# Altium schematic sheet DIGITAL_INPUT.SchDoc(DIGITAL_INPUT)
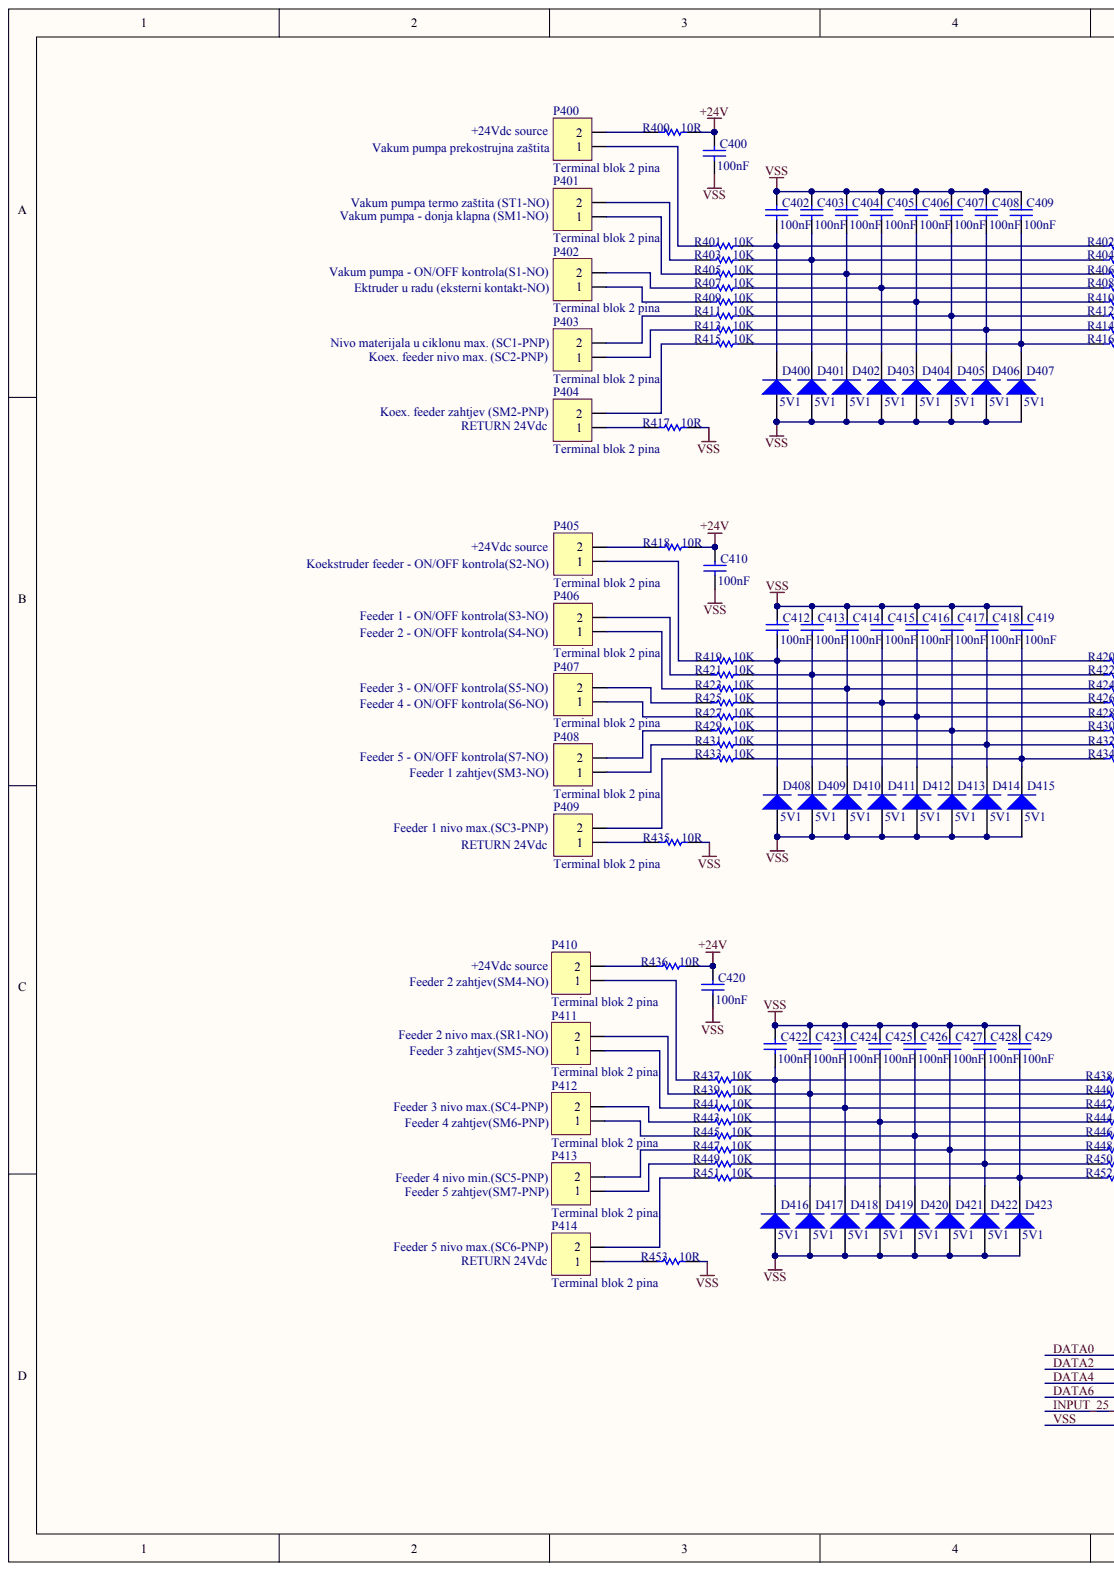
[diagram p0: DIGITAL_INPUT.SchDoc, left]
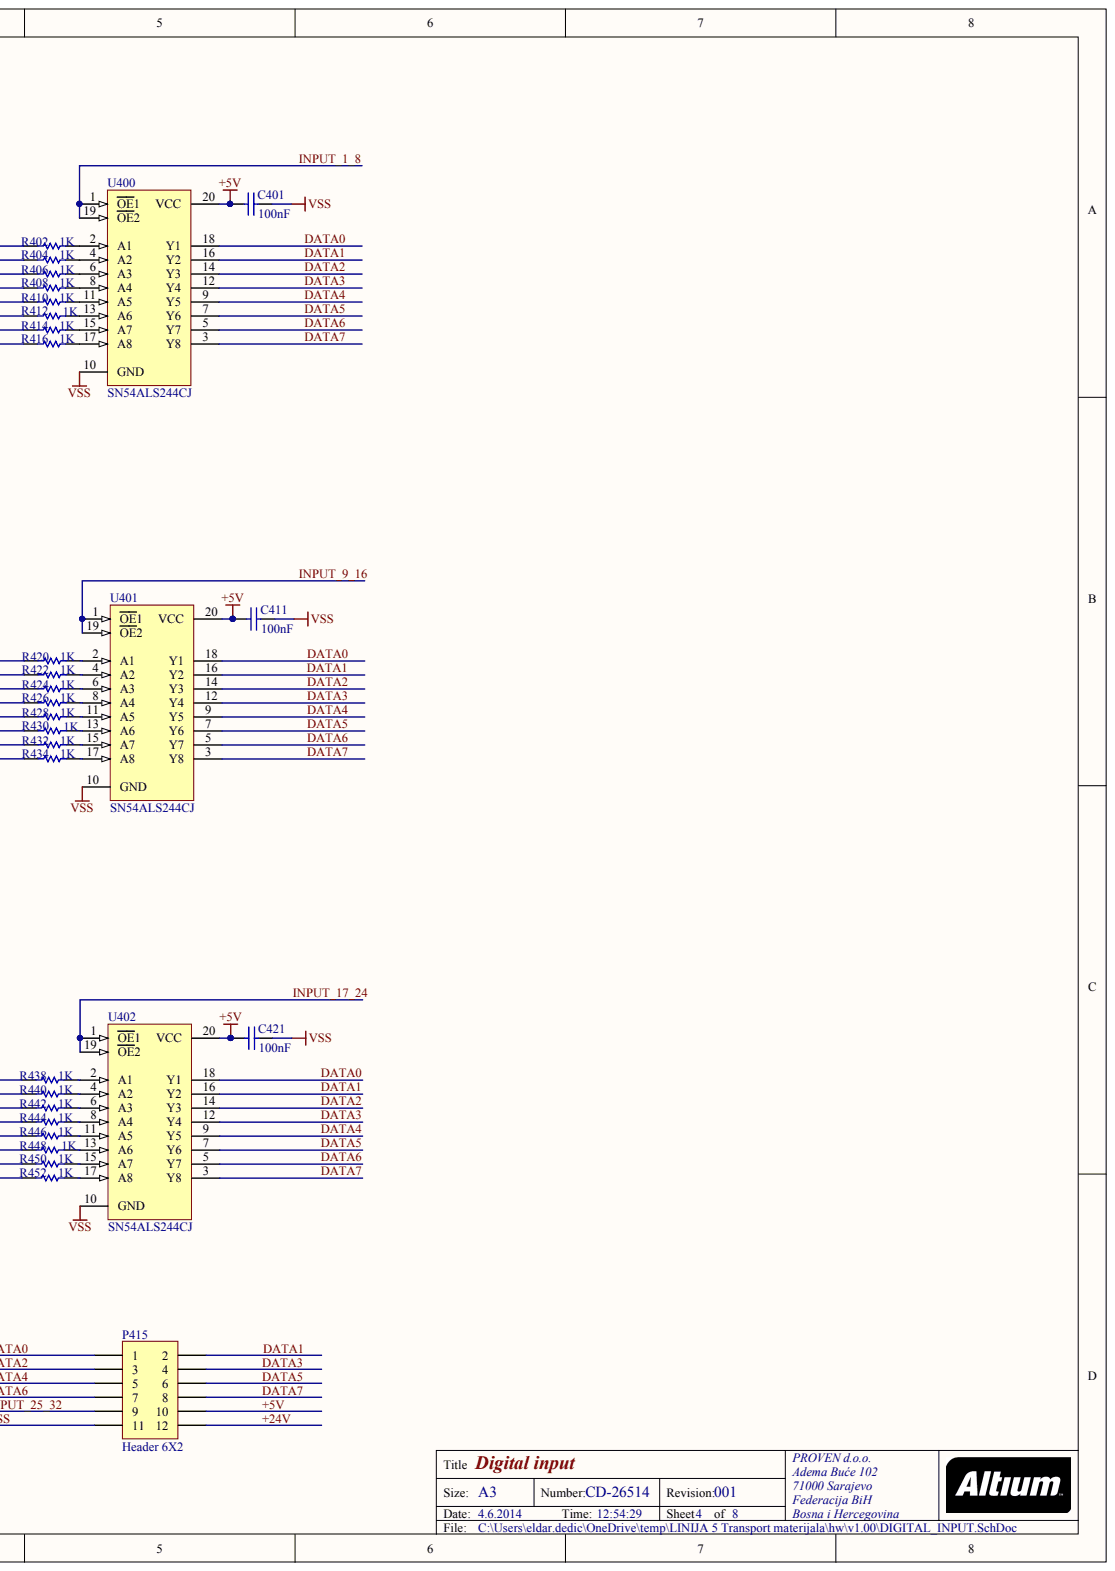
[diagram p1: DIGITAL_INPUT.SchDoc, right]

## Parts
C400  value 100nF
C401  value 100nF
C402  value 100nF
C403  value 100nF
C404  value 100nF
C405  value 100nF
C406  value 100nF
C407  value 100nF
C408  value 100nF
C409  value 100nF
C410  value 100nF
C411  value 100nF
C412  value 100nF
C413  value 100nF
C414  value 100nF
C415  value 100nF
C416  value 100nF
C417  value 100nF
C418  value 100nF
C419  value 100nF
C420  value 100nF
C421  value 100nF
C422  value 100nF
C423  value 100nF
C424  value 100nF
C425  value 100nF
C426  value 100nF
C427  value 100nF
C428  value 100nF
C429  value 100nF
D400  5V1
D401  5V1
D402  5V1
D403  5V1
D404  5V1
D405  5V1
D406  5V1
D407  5V1
D408  5V1
D409  5V1
D410  5V1
D411  5V1
D412  5V1
D413  5V1
D414  5V1
D415  5V1
D416  5V1
D417  5V1
D418  5V1
D419  5V1
D420  5V1
D421  5V1
D422  5V1
D423  5V1
P400  Terminal blok 2 pina
P401  Terminal blok 2 pina
P402  Terminal blok 2 pina
P403  Terminal blok 2 pina
P404  Terminal blok 2 pina
P405  Terminal blok 2 pina
P406  Terminal blok 2 pina
P407  Terminal blok 2 pina
P408  Terminal blok 2 pina
P409  Terminal blok 2 pina
P410  Terminal blok 2 pina
P411  Terminal blok 2 pina
P412  Terminal blok 2 pina
P413  Terminal blok 2 pina
P414  Terminal blok 2 pina
P415  Header 6X2
R400  10R
R401  10K
R402  1K
R403  10K
R404  1K
R405  10K
R406  1K
R407  10K
R408  1K
R409  10K
R410  1K
R411  10K
R412  1K
R413  10K
R414  1K
R415  10K
R416  1K
R417  10R
R418  10R
R419  10K
R420  1K
R421  10K
R422  1K
R423  10K
R424  1K
R425  10K
R426  1K
R427  10K
R428  1K
R429  10K
R430  1K
R431  10K
R432  1K
R433  10K
R434  1K
R435  10R
R436  10R
R437  10K
R438  1K
R439  10K
R440  1K
R441  10K
R442  1K
R443  10K
R444  1K
R445  10K
R446  1K
R447  10K
R448  1K
R449  10K
R450  1K
R451  10K
R452  1K
R453  10R
U400  SN54ALS244CJ
U401  SN54ALS244CJ
U402  SN54ALS244CJ

## Nets
+5V: C401.1 C411.1 C421.1 P415.10 U400.20(VCC) U401.20(VCC) U402.20(VCC)
+24V: C400.2 C410.2 C420.2 P415.12 R400.2 R418.2 R436.2
DATA0: P415.1 U400.18(Y1) U401.18(Y1) U402.18(Y1)
DATA1: P415.2 U400.16(Y2) U401.16(Y2) U402.16(Y2)
DATA2: P415.3 U400.14(Y3) U401.14(Y3) U402.14(Y3)
DATA3: P415.4 U400.12(Y4) U401.12(Y4) U402.12(Y4)
DATA4: P415.5 U400.9(Y5) U401.9(Y5) U402.9(Y5)
DATA5: P415.6 U400.7(Y6) U401.7(Y6) U402.7(Y6)
DATA6: P415.7 U400.5(Y7) U401.5(Y7) U402.5(Y7)
DATA7: P415.8 U400.3(Y8) U401.3(Y8) U402.3(Y8)
INPUT_1_8: U400.1(OE1) U400.19(OE2)
INPUT_9_16: U401.1(OE1) U401.19(OE2)
INPUT_17_24: U402.1(OE1) U402.19(OE2)
INPUT_25_32: P415.9
VSS: C400.1 C401.2 C402.2 C403.2 C404.2 C405.2 C406.2 C407.2 C408.2 C409.2 C410.1 C411.2 C412.2 C413.2 C414.2 C415.2 C416.2 C417.2 C418.2 C419.2 C420.1 C421.2 C422.2 C423.2 C424.2 C425.2 C426.2 C427.2 C428.2 C429.2 D400.1 D401.1 D402.1 D403.1 D404.1 D405.1 D406.1 D407.1 D408.1 D409.1 D410.1 D411.1 D412.1 D413.1 D414.1 D415.1 D416.1 D417.1 D418.1 D419.1 D420.1 D421.1 D422.1 D423.1 P415.11 R417.2 R435.2 R453.2 U400.10(GND) U401.10(GND) U402.10(GND)
NetC402_1: C402.1 D400.2 R401.2 R402.1
NetC403_1: C403.1 D401.2 R403.2 R404.1
NetC404_1: C404.1 D402.2 R405.2 R406.1
NetC405_1: C405.1 D403.2 R407.2 R408.1
NetC406_1: C406.1 D404.2 R409.2 R410.1
NetC407_1: C407.1 D405.2 R411.2 R412.1
NetC408_1: C408.1 D406.2 R413.2 R414.1
NetC409_1: C409.1 D407.2 R415.2 R416.1
NetC412_1: C412.1 D408.2 R419.2 R420.1
NetC413_1: C413.1 D409.2 R421.2 R422.1
NetC414_1: C414.1 D410.2 R423.2 R424.1
NetC415_1: C415.1 D411.2 R425.2 R426.1
NetC416_1: C416.1 D412.2 R427.2 R428.1
NetC417_1: C417.1 D413.2 R429.2 R430.1
NetC418_1: C418.1 D414.2 R431.2 R432.1
NetC419_1: C419.1 D415.2 R433.2 R434.1
NetC422_1: C422.1 D416.2 R437.2 R438.1
NetC423_1: C423.1 D417.2 R439.2 R440.1
NetC424_1: C424.1 D418.2 R441.2 R442.1
NetC425_1: C425.1 D419.2 R443.2 R444.1
NetC426_1: C426.1 D420.2 R445.2 R446.1
NetC427_1: C427.1 D421.2 R447.2 R448.1
NetC428_1: C428.1 D422.2 R449.2 R450.1
NetC429_1: C429.1 D423.2 R451.2 R452.1
NetP400_1: P400.1 R401.1
NetP400_2: P400.2 R400.1
NetP401_1: P401.1 R405.1
NetP401_2: P401.2 R403.1
NetP402_1: P402.1 R409.1
NetP402_2: P402.2 R407.1
NetP403_1: P403.1 R413.1
NetP403_2: P403.2 R411.1
NetP404_1: P404.1 R417.1
NetP404_2: P404.2 R415.1
NetP405_1: P405.1 R419.1
NetP405_2: P405.2 R418.1
NetP406_1: P406.1 R423.1
NetP406_2: P406.2 R421.1
NetP407_1: P407.1 R427.1
NetP407_2: P407.2 R425.1
NetP408_1: P408.1 R431.1
NetP408_2: P408.2 R429.1
NetP409_1: P409.1 R435.1
NetP409_2: P409.2 R433.1
NetP410_1: P410.1 R437.1
NetP410_2: P410.2 R436.1
NetP411_1: P411.1 R441.1
NetP411_2: P411.2 R439.1
NetP412_1: P412.1 R445.1
NetP412_2: P412.2 R443.1
NetP413_1: P413.1 R449.1
NetP413_2: P413.2 R447.1
NetP414_1: P414.1 R453.1
NetP414_2: P414.2 R451.1
NetR402_2: R402.2 U400.2(A1)
NetR404_2: R404.2 U400.4(A2)
NetR406_2: R406.2 U400.6(A3)
NetR408_2: R408.2 U400.8(A4)
NetR410_2: R410.2 U400.11(A5)
NetR412_2: R412.2 U400.13(A6)
NetR414_2: R414.2 U400.15(A7)
NetR416_2: R416.2 U400.17(A8)
NetR420_2: R420.2 U401.2(A1)
NetR422_2: R422.2 U401.4(A2)
NetR424_2: R424.2 U401.6(A3)
NetR426_2: R426.2 U401.8(A4)
NetR428_2: R428.2 U401.11(A5)
NetR430_2: R430.2 U401.13(A6)
NetR432_2: R432.2 U401.15(A7)
NetR434_2: R434.2 U401.17(A8)
NetR438_2: R438.2 U402.2(A1)
NetR440_2: R440.2 U402.4(A2)
NetR442_2: R442.2 U402.6(A3)
NetR444_2: R444.2 U402.8(A4)
NetR446_2: R446.2 U402.11(A5)
NetR448_2: R448.2 U402.13(A6)
NetR450_2: R450.2 U402.15(A7)
NetR452_2: R452.2 U402.17(A8)

## Library data of the parts
R400-R453 = (no symbol name): Note: If flow soldering, adjust pad width of PCB Footprint to 0.7 - 0.8 times the width of the resistor.; Published: 17-Dec-2003; LatestRevisionDate: 19-Mar-2004; LatestRevisionNote: Silkscreen size reduced.; PackageDocument: Mar-2003; PackageReference: ERA-0805; Code_IPC: 2012 [0805]; ComponentLink1Description: Manufacturer Link; ComponentLink1URL: http://www.panasonic.com/industrial/components/resistive_products.htm; ComponentLink2Description: Datasheet; ComponentLink2URL: http://www.panasonic.com/industrial/components/pdf/AOA0000CE8.pdf; Publisher: Altium Limited
C400-C429 D400-D423 P400-P415 U400-U402 = (no symbol name)
  C400-C429: Published: 17-Jul-2002; LatestRevisionDate: 19-Mar-2004; LatestRevisionNote: Silkscreen size reduced.; DatasheetDocument: Mar-2001; PackageDocument: Mar-2001; PackageReference: 1206; Code_JEITA: 1206; Code_IPC: 3216 [1206]; ComponentLink1Description: Manufacturer Link; ComponentLink1URL: http://www.kemet.com/; ComponentLink2Description: Datasheet; ComponentLink2URL: http://www.kemet.com/kemet/web/homepage/kechome.nsf/vapubfilesname/F3102TA.pdf/$file/F3102TA.pdf
  D400-D423: Published: 17-Sep-2003; DatasheetVersion: 11-May-1999; PackageVersion: May-1999; PackageReference: SOD66; PackageDescription: Diode, Axial; Body 4.8 x 2.6 mm (LxDia. max), Lead Dia. 0.81 mm (max); Code_JEDEC: DO-204-AL; ComponentLink1Description: Manufacturer Link; ComponentLink1URL: http://www.semiconductors.philips.com/; ComponentLink2Description: Datasheet; ComponentLink2URL: http://www.semiconductors.philips.com/acrobat/datasheets/BZV85_2.pdf
  P415: LatestRevisionDate: 17-Jul-2002; LatestRevisionNote: Re-released for DXP Platform.
  U400-U402: Published: 8-Jun-2000; LatestRevisionDate: 06-Jun-2005; LatestRevisionNote: Stylized 3D Model Added.; DatasheetVersion: Aug-1995; PackageVersion: Jun-1999; PackageReference: J020; PackageDescription: DIP; 20 Leads; Row Spacing 7.62 mm; Pitch 2.54 mm; ComponentLink1Description: Manufacturer Link; ComponentLink1URL: http://www.ti.com/; ComponentLink2Description: Datasheet; ComponentLink2URL: http://www-s.ti.com/sc/ds/sn74als244c.pdf
  C400-C429 D400-D423 P415 U400-U402: Publisher: Altium Limited
